# Altium schematic sheet Measure.SchDoc
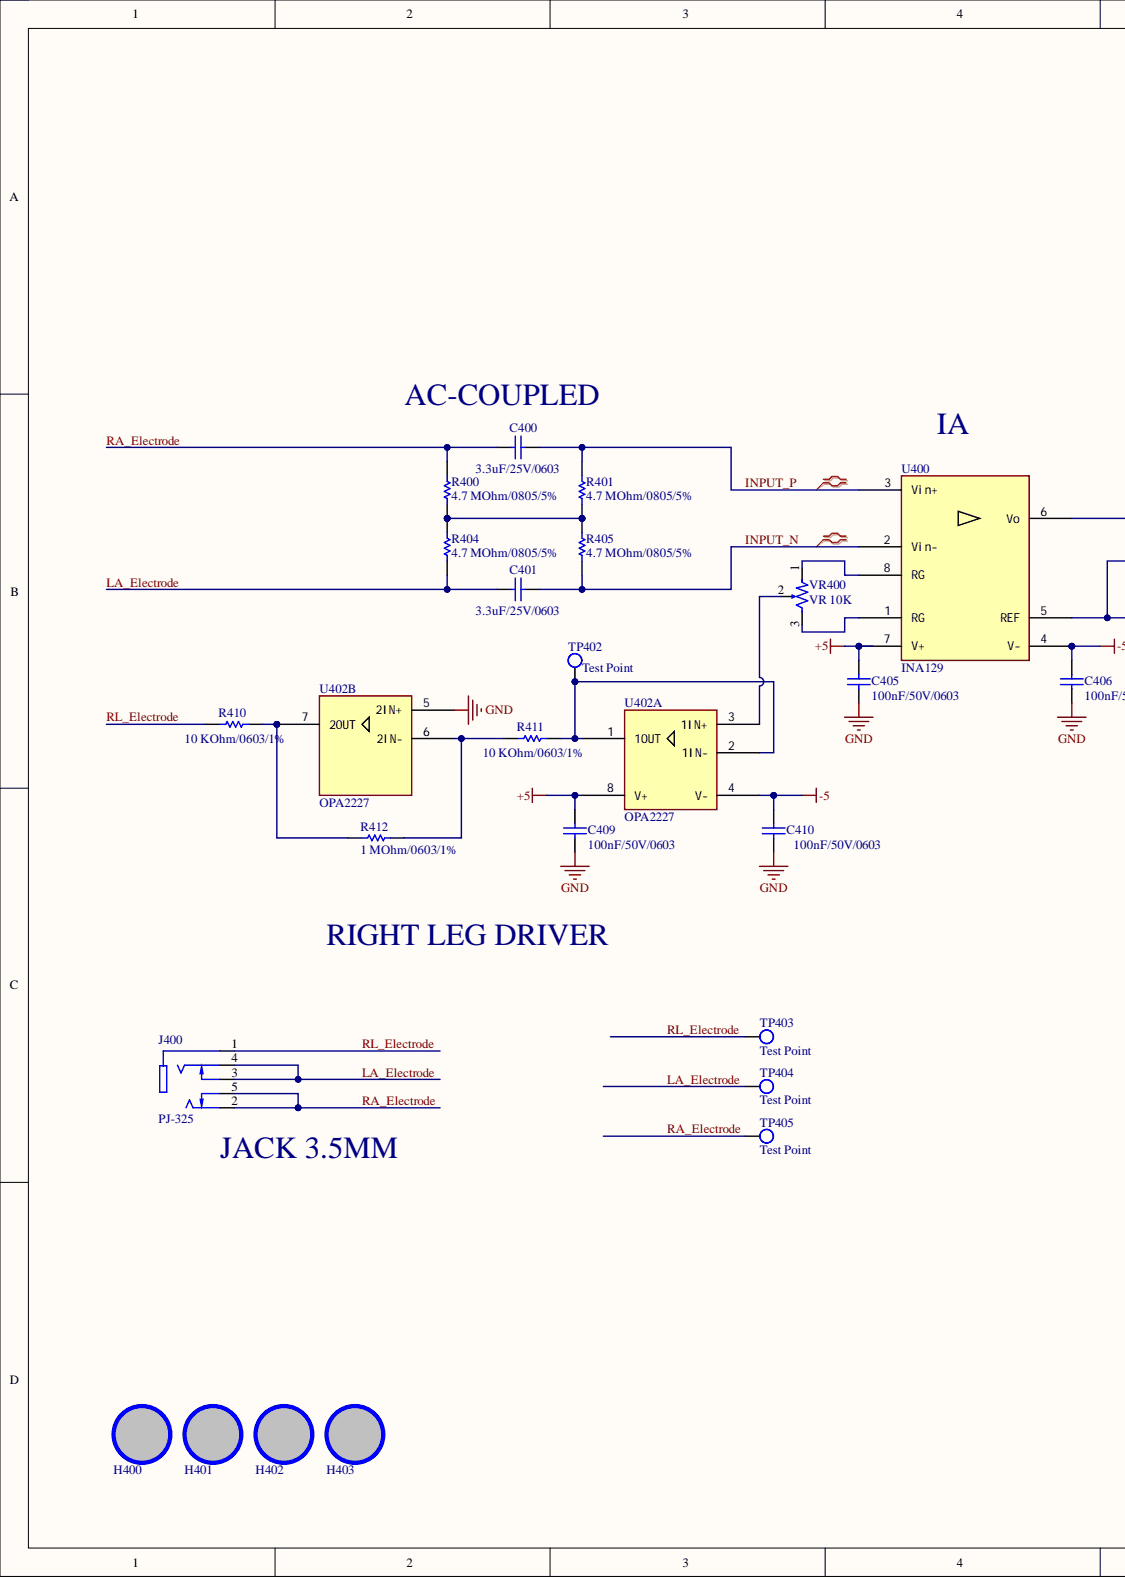
[diagram p0: Measure.SchDoc, left]
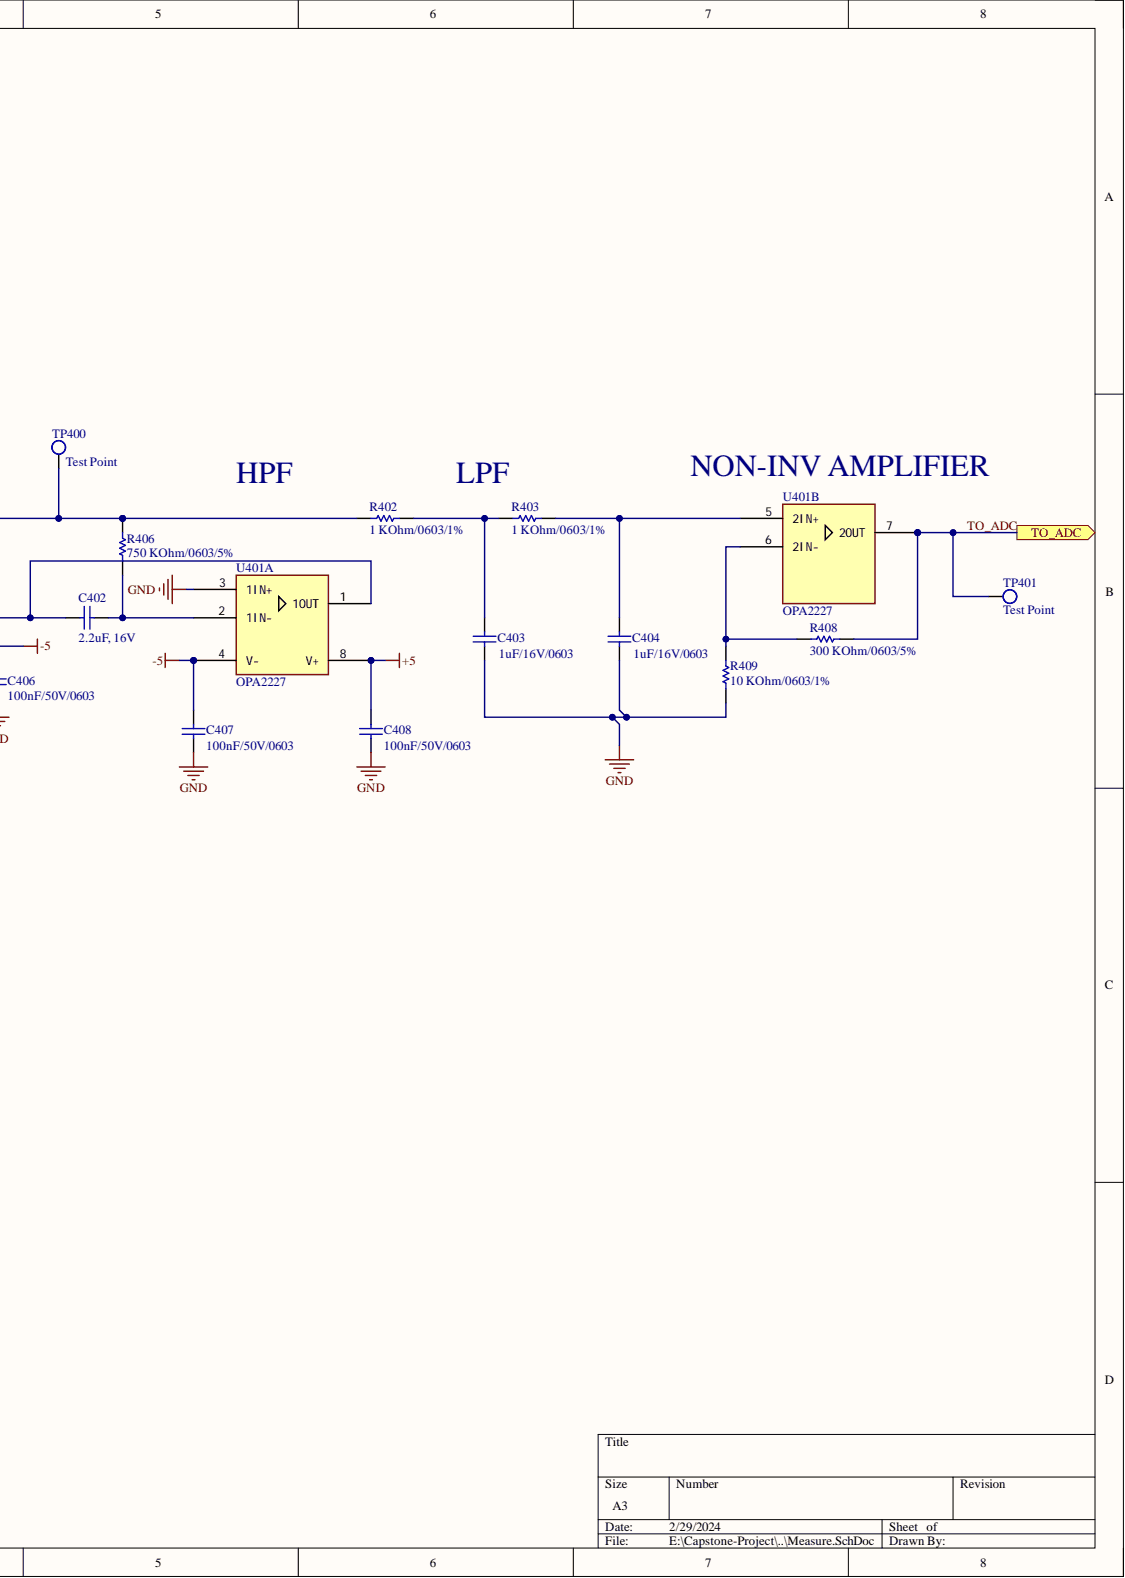
[diagram p1: Measure.SchDoc, right]

## Parts
C400  3.3uF, 25V  value 3.3uF/25V/0603  [WCAP-CSGP_0805_H=1.25mm]
C401  3.3uF, 25V  value 3.3uF/25V/0603  [WCAP-CSGP_0805_H=1.25mm]
C402  2.2uF, 16V  [WCAP-CSGP_0603_H=0.8mm_Reflow]
C403  1uF, 16V  value 1uF/16V/0603  [WCAP-CSGP_0603_H=0.8mm_Reflow]
C404  1uF, 16V  value 1uF/16V/0603  [WCAP-CSGP_0603_H=0.8mm_Reflow]
C405  value 100nF/50V/0603  [WCAP-CSGP_0603_H=0.8mm_Reflow]  (hidden comment: 100nF, 25V)
C406  value 100nF/50V/0603  [WCAP-CSGP_0603_H=0.8mm_Reflow]  (hidden comment: 100nF, 25V)
C407  value 100nF/50V/0603  [WCAP-CSGP_0603_H=0.8mm_Reflow]  (hidden comment: 100nF, 25V)
C408  value 100nF/50V/0603  [WCAP-CSGP_0603_H=0.8mm_Reflow]  (hidden comment: 100nF, 25V)
C409  value 100nF/50V/0603  [WCAP-CSGP_0603_H=0.8mm_Reflow]  (hidden comment: 100nF, 25V)
C410  value 100nF/50V/0603  [WCAP-CSGP_0603_H=0.8mm_Reflow]  (hidden comment: 100nF, 25V)
H400  Hole  [LO KHOAN 3MM]  (value not drawn)
H401  Hole  [LO KHOAN 3MM]  (value not drawn)
H402  Hole  [LO KHOAN 3MM]  (value not drawn)
H403  Hole  [LO KHOAN 3MM]  (value not drawn)
J400  value PJ-325  [3.5 mm, Strereo]  (hidden comment: PJ-324)
R400  value 4.7 MOhm/0805/5%  [R0805]  (hidden comment: Resistor 4.7 MOhm 0805 5%)
R401  value 4.7 MOhm/0805/5%  [R0805]  (hidden comment: Resistor 4.7 MOhm 0805 5%)
R402  value 1 KOhm/0603/1%  [R0603]  (hidden comment: Resistor 1 KOhm 0603 1%)
R403  value 1 KOhm/0603/1%  [R0603]  (hidden comment: Resistor 1 KOhm 0603 1%)
R404  value 4.7 MOhm/0805/5%  [R0805]  (hidden comment: Resistor 4.7 MOhm 0805 5%)
R405  value 4.7 MOhm/0805/5%  [R0805]  (hidden comment: Resistor 4.7 MOhm 0805 5%)
R406  value 750 KOhm/0603/5%  [R0603]  (hidden comment: Resistor 750 KOhm 0603 5%)
R408  value 300 KOhm/0603/5%  [R0603]  (hidden comment: Resistor 300 KOhm 0603 5%)
R409  value 10 KOhm/0603/1%  [R0603]  (hidden comment: Resistor 10 KOhm 0603 1%)
R410  value 10 KOhm/0603/1%  [R0603]  (hidden comment: Resistor 10 KOhm 0603 1%)
R411  value 10 KOhm/0603/1%  [R0603]  (hidden comment: Resistor 10 KOhm 0603 1%)
R412  value 1 MOhm/0603/1%  [R0603]  (hidden comment: Resistor 1 MOhm 0603 1%)
TP400  Test Point  [TP]
TP401  Test Point  [TP]
TP402  Test Point  [TP]
TP403  Test Point  [TP]
TP404  Test Point  [TP]
TP405  Test Point  [TP]
U400  INA129  [SOIC-8]
U401  OPA2227  [SOIC-8]  (parts A,B)
U402  OPA2227  [SOIC-8]  (parts A,B)
VR400  10K  value VR 10K  [VARISTOR 2]

## Ports
TO_ADC

## Nets
+5: C405.2 C408.1 C409.2 U400.7(V+) U401.8(V+) U402.8(V+)
-5: C406.1 C407.2 C410.1 U400.4(V-) U401.4(V-) U402.4(V-)
GND: C403.1 C404.1 C405.1 C406.2 C407.1 C408.2 C409.1 C410.2 R409.1 U401.3(1IN+) U402.5(2IN+)
INPUT_N: C401.2 R405.1 U400.2(Vin-)
INPUT_P: C400.2 R401.2 U400.3(Vin+)
LA_Electrode: C401.1 J400.3 J400.4 R404.1 TP404.TP1
RA_Electrode: C400.1 J400.2 J400.5 R400.2 TP405.TP1
RL_Electrode: J400.1 R410.1 TP403.TP1
TO_ADC: R408.2 TP401.TP1 U401.7(2OUT) ; port TO_ADC
NetC402_1: C402.1 R406.1 U401.2(1IN-)
NetC402_2: C402.2 U400.5(REF) U401.1(1OUT)
NetC403_2: C403.2 R402.2 R403.1
NetC404_2: C404.2 R403.2 U401.5(2IN+)
NetR400_1: R400.1 R401.1 R404.2 R405.2
NetR402_1: R402.1 R406.2 TP400.TP1 U400.6(Vo)
NetR408_1: R408.1 R409.2 U401.6(2IN-)
NetR410_2: R410.2 R412.1 U402.7(2OUT)
NetR411_1: R411.1 R412.2 U402.6(2IN-)
NetR411_2: R411.2 TP402.TP1 U402.1(1OUT) U402.2(1IN-)
NetU400_1: U400.1(RG) VR400.3
NetU400_8: U400.8(RG) VR400.1
NetU402_3: U402.3(1IN+) VR400.2

## Library data of the parts
C400 C401 = 885012107017: WCAP-CSGP Multilayer Ceramic Chip Capacitor, General Purpose, size 0805, X5R, 3.3uF, 25V; Manufacturer: Wurth Elektronik; Capacitance Tolerance: +/-20%; Dissipation Factor: #NAME?; Isolation Resistance: >=0.03GOhm; Rated Voltage: 25VDC; Technical Reference: X5R0805335M025DFCT10000; Ceramic Type: X5R; Component Kind: Standard; ComponentLink1Description: Manufacturer; ComponentLink1URL: http://www.we-online.de; ComponentLink2Description: Datasheet; ComponentLink2URL: https://www.we-online.com/catalog/datasheet/885012107017.pdf; Height: 1.25mm; Library Name: Altium_WCAP-CSGP (rev20a).IntLib; Manufacturer Part Number: 885012107017; Mounting Technology: SMT; Operating Temperature: -55 to +85degC; Packaging: Tape and Reel; Published Date: May 2020; Size: 0805
C402 = 885012106018: WCAP-CSGP Multilayer Ceramic Chip Capacitor, General Purpose, size 0603, X5R, 2.2uF, 16V; Manufacturer: Wurth Elektronik; Capacitance Tolerance: +/-20%; Dissipation Factor: #NAME?; Isolation Resistance: >=0.05GOhm; Rated Voltage: 16VDC; Technical Reference: X5R0603225M016DFCT10000; Capacitance: 2.2uF; Ceramic Type: X5R; Component Kind: Standard; ComponentLink1Description: Manufacturer; ComponentLink1URL: http://www.we-online.de; ComponentLink2Description: Datasheet; ComponentLink2URL: https://www.we-online.com/catalog/datasheet/885012106018.pdf; Height: 0.8mm; Library Name: Altium_WCAP-CSGP (rev20a).IntLib; Manufacturer Part Number: 885012106018; Mounting Technology: SMT; Operating Temperature: -55 to +85degC; Packaging: Tape and Reel; Published Date: May 2020; Size: 0603
C403 C404 = 885012106017: WCAP-CSGP Multilayer Ceramic Chip Capacitor, General Purpose, size 0603, X5R, 1uF, 16V; Manufacturer: Wurth Elektronik; Capacitance Tolerance: +/-20%; Dissipation Factor: #NAME?; Isolation Resistance: >=0.1GOhm; Rated Voltage: 16VDC; Technical Reference: X5R0603105M016DFCT10000; Ceramic Type: X5R; Component Kind: Standard; ComponentLink1Description: Manufacturer; ComponentLink1URL: http://www.we-online.de; ComponentLink2Description: Datasheet; ComponentLink2URL: https://www.we-online.com/catalog/datasheet/885012106017.pdf; Height: 0.8mm; Library Name: Altium_WCAP-CSGP (rev20a).IntLib; Manufacturer Part Number: 885012106017; Mounting Technology: SMT; Operating Temperature: -55 to +85degC; Packaging: Tape and Reel; Published Date: May 2020; Size: 0603
C405-C410 = 885012206071: WCAP-CSGP Multilayer Ceramic Chip Capacitor, General Purpose, size 0603, X7R, 100nF, 25V; Manufacturer: Wurth Elektronik; Capacitance Tolerance: +/-10%; Dissipation Factor: #NAME?; Isolation Resistance: >=5GOhm; Rated Voltage: 25VDC; Technical Reference: X7R0603104K025DFCT10000; Ceramic Type: X7R; Component Kind: Standard; ComponentLink1Description: Manufacturer; ComponentLink1URL: http://www.we-online.de; ComponentLink2Description: Datasheet; ComponentLink2URL: https://www.we-online.com/catalog/datasheet/885012206071.pdf; Height: 0.8mm; Library Name: Altium_WCAP-CSGP (rev20a).IntLib; Manufacturer Part Number: 885012206071; Mounting Technology: SMT; Operating Temperature: -55 to +125degC; Packaging: Tape and Reel; Published Date: May 2020; Size: 0603
H400-H403 = Hole: Hole 3mm; Component Kind: Standard; Library Name: PIF_Library.IntLib
J400 = PJ-324: Jack 3.5 3-Pole 5-Pin; Component Kind: Standard; ComponentLink1Description: Buy; ComponentLink1URL: https://www.thegioiic.com/pj-324-cong-audio-3-5mm-3-cuc-5-chan-han-pcb; Library Name: Giang's Libs.IntLib
R400 R401 R404 R405 = Resistor 4.7 MOhm 0805 5%: Code 475, 1/8W; Component Kind: Standard; ComponentLink1Description: Buy; ComponentLink1URL: https://www.thegioiic.com/dien-tro-4-7-mohm-0805-5-; Library Name: Giang_Resistor.IntLib
R402 R403 = Resistor 1 KOhm 0603 1%: Code 102, 1/10W; Component Kind: Standard; ComponentLink1Description: Buy; ComponentLink1URL: https://www.thegioiic.com/dien-tro-1-kohm-0603-1; Library Name: Giang_Resistor.IntLib
R406 = Resistor 750 KOhm 0603 5%: Code 754, 1/10W; Component Kind: Standard; Library Name: Giang_Resistor.IntLib
R408 = Resistor 300 KOhm 0603 5%: Code 304, 1/10W; Component Kind: Standard; Library Name: Giang_Resistor.IntLib
R409 = Resistor 10 KOhm 0603 1%: Code 103, 1/10W; Component Kind: Standard; ComponentLink1Description: Buy; ComponentLink1URL: https://www.thegioiic.com/dien-tro-10-kohm-0603-1-; Library Name: Giang_Resistor.IntLib
R410 R411 = Resistor 10 KOhm 0603 1%: Code 103, 1/10W; Value: 10 KOhm/0603/1%; Component Kind: Standard; ComponentLink1Description: Buy; ComponentLink1URL: https://www.thegioiic.com/dien-tro-10-kohm-0603-1-; Library Name: Giang_Resistor.IntLib
R412 = Resistor 1 MOhm 0603 1%: Code 105, 1/10W; Component Kind: Standard; ComponentLink1Description: Buy; ComponentLink1URL: https://www.thegioiic.com/dien-tro-1-mohm-0603-1-; Library Name: Giang_Resistor.IntLib
U400 = INA129: Precision, Low Power Instrumentation Amplifiers; Component Kind: Standard; ComponentLink1Description: Buy; ComponentLink2Description: Datasheet; ComponentLink2URL: https://www.ti.com/lit/ds/symlink/ina129-ep.pdf?ts=1697381225295&ref_url=https%253A%252F%252Fwww.google.com%252F; Value: INA129; Library Name: Giang_OPAMP.IntLib
U401 U402 = OPA2227: High-Precision, Low-Noise Operational Amplifiers; Component Kind: Standard; ComponentLink1Description: Datasheet; ComponentLink1URL: https://www.ti.com/lit/ds/symlink/opa2227.pdf?ts=1697381749451&ref_url=https%253A%252F%252Fwww.ti.com%252Fproduct%252FOPA2227; Value: OPA2227; Library Name: Giang_OPAMP.IntLib
VR400 = Var Resistor: Component Kind: Standard; Description: Var Resistor; Library Name: PIF_Library.IntLib
TP400-TP405 = Test Point: Component Kind: Standard; Library Name: PIF_Library.IntLib
